# Altium schematic sheet 02_Power.SchDoc
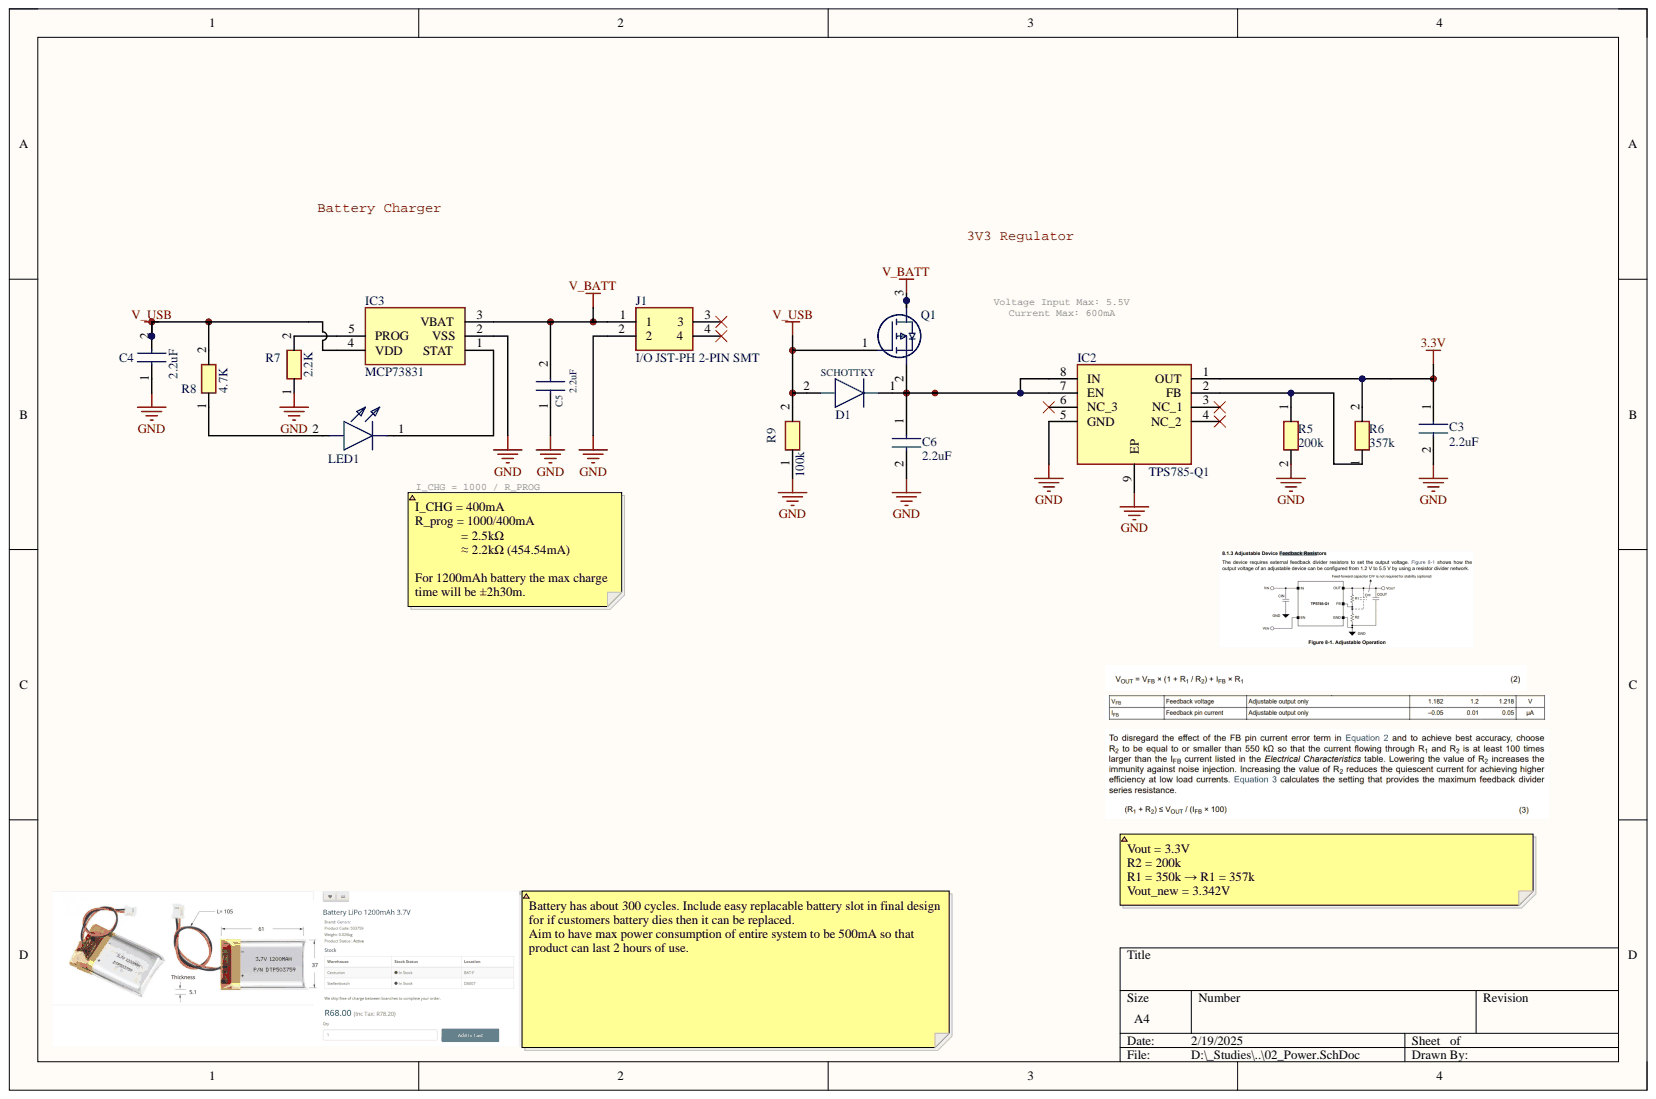
[diagram p0: 02_Power.SchDoc]

## Parts
C3  2.2uF  [CAPC2012X135N]
C4  2.2uF  [CAPC2012X135N]
C5  2.2uF  [CAPC2012X135N]
C6  2.2uF  [CAPC2012X135N]
D1  SCHOTTKY  [SOD2512X113N]
IC2  TPS785-Q1  [SON65P300X300X100-9N-D]
IC3  MCP73831  [SOT95P270X145-5N]
J1  I/O JST-PH 2-PIN SMT  [1769]
LED1  LED YELLOW 0805 SMD  [LYM676Q2S126Z]  (value not drawn)
Q1  MOSFET P-CH ENH FET -20V 52mOh -5A SMD  [SOT96P240X115-3N]  (value not drawn)
R5  200k  [RESC2012X60N]
R6  357k  [ERJ6ENF1000V]
R7  2.2K  [RESC2012X70N]
R8  4.7K  [RESC2012X50N]
R9  100k  [RESC2012X50N]

## Nets
3.3V: C3.1 IC2.1(OUT) R6.2
GND: C3.2 C4.1 C5.1 C6.2 IC2.5(GND) IC2.9(EP) IC3.2(VSS) J1.2 R5.2 R7.1 R9.1
V_BATT: C5.2 IC3.3(VBAT) J1.1 Q1.3
V_USB: C4.2 D1.2 IC3.4(VDD) Q1.1 R8.2 R9.2
NetC6_1: C6.1 D1.1 IC2.7(EN) IC2.8(IN) Q1.2
NetIC2_2: IC2.2(FB) R5.1 R6.1
NetIC3_1: IC3.1(STAT) LED1.1
NetIC3_5: IC3.5(PROG) R7.2
NetLED1_2: LED1.2 R8.1

Not connected: IC2 3(NC_1) 4(NC_2) 6(NC_3); J1 3 4

## Library data of the parts
C3-C6 = CAP CER 2u2F 0805 SMD: Capacitor; Manufacturer_Name: TAIYO YUDEN; Manufacturer_Part_Number: LMK212BJ225MG-T; Component Kind: Standard; Datasheet Link: https://ds.yuden.co.jp/TYCOMPAS/ut/download?pn=LMK063BBJ474MPLF&fileType=CA; Height: 1.35mm; Mouser Part Number: 963-LMK212BJ225MG-T; Mouser Price/Stock: https://www.mouser.com/Search/Refine.aspx?Keyword=963-LMK212BJ225MG-T; Library Name: BraaiBuddyPCB_Schematic_Library.SCHLIB
D1 = DIODE SCHOTTKY 1A 23V 620mV SMD: Diode; Manufacturer_Name: STMicroelectronics; Manufacturer_Part_Number: BAT20JFILM; Arrow Part Number: BAT20JFILM; Arrow Price/Stock: https://www.arrow.com/en/products/bat20jfilm/stmicroelectronics?utm_currency=USD&region=nac; Component Kind: Standard; ComponentLink1Description: Datasheet; ComponentLink1URL: http://www.st.com/web/en/resource/technical/document/datasheet/CD00012201.pdf; Datasheet Link: http://www.st.com/web/en/resource/technical/document/datasheet/CD00012201.pdf; Height: 1.13mm; Mouser Part Number: 511-BAT20JFILM; Mouser Price/Stock: https://www.mouser.co.uk/ProductDetail/STMicroelectronics/BAT20JFILM?qs=6cWmAGMIEBzKXM4JcoRdbw%3D%3D; Library Name: BraaiBuddyPCB_Schematic_Library.SCHLIB
IC2 = IC LDO 1A 5V5 ADJ SMD SON-8: LDO Voltage Regulators Automotive 1-A high -accuracy adjustable; Manufacturer_Name: Texas Instruments; Manufacturer_Part_Number: TPS78501QWDRBRQ1; Arrow Part Number: TPS78501QWDRBRQ1; Arrow Price/Stock: https://www.arrow.com/en/products/tps78501qwdrbrq1/texas-instruments?region=nac; Component Kind: Standard; ComponentLink1Description: Datasheet; ComponentLink1URL: https://www.ti.com/lit/gpn/TPS785-Q1; Datasheet Link: https://www.ti.com/lit/gpn/TPS785-Q1; Height: 1mm; Mouser Part Number: 595-TPS78501QWDRBRQ1; Mouser Price/Stock: https://www.mouser.co.uk/ProductDetail/Texas-Instruments/TPS78501QWDRBRQ1?qs=iLbezkQI%252Bsjtafwbr0PHJA%3D%3D; Library Name: BraaiBuddyPCB_Schematic_Library.SCHLIB
IC3 = IC BATT CHG MGNT Li-Po 4V2 REG SMD: Integrated Circuit; Manufacturer_Name: Microchip; Manufacturer_Part_Number: MCP73831T-2ACI/OT; Arrow Part Number: MCP73831T-2ACI/OT; Arrow Price/Stock: https://www.arrow.com/en/products/mcp73831t-2aciot/microchip-technology?utm_currency=USD&region=nac; Component Kind: Standard; ComponentLink1Description: Datasheet; ComponentLink1URL: http://ww1.microchip.com/downloads/en/DeviceDoc/20001984g.pdf; Datasheet Link: http://ww1.microchip.com/downloads/en/DeviceDoc/20001984g.pdf; Height: 1.45mm; Mouser Part Number: 579-MCP73831T-2ACIOT; Mouser Price/Stock: https://www.mouser.co.uk/ProductDetail/Microchip-Technology/MCP73831T-2ACI-OT?qs=yUQqVecv4qvbBQBGbHx0Mw%3D%3D; Library Name: BraaiBuddyPCB_Schematic_Library.SCHLIB
J1 = I/O JST-PH 2-PIN SMT: Connector; Manufacturer_Name: Adafruit; Arrow Part Number: 1769; Arrow Price/Stock: https://www.arrow.com/en/products/1769/adafruit-industries?utm_currency=USD&region=nac; Component Kind: Standard; Datasheet Link: https://cdn-shop.adafruit.com/datasheets/17311.pdf; Height: 5.5mm; Manufacturer_Part_Number: 1769; Mouser Part Number: 485-1769; Mouser Price/Stock: https://www.mouser.co.uk/ProductDetail/Adafruit/1769?qs=GURawfaeGuBbgoGtf2XstA%3D%3D; Library Name: BraaiBuddyPCB_Schematic_Library.SCHLIB
LED1 = LED YELLOW 0805 SMD: Single Colour LED Yellow 20mA; Manufacturer_Name: ams OSRAM; Manufacturer_Part_Number: LY M676-Q2S1-26-Z; Component Kind: Standard; ComponentLink1Description: Datasheet; ComponentLink1URL: https://www.mouser.com/datasheet/2/588/Osram_7222021_LY__M676_EN-2891431.pdf; Datasheet Link: https://www.mouser.com/datasheet/2/588/Osram_7222021_LY__M676_EN-2891431.pdf; Height: 1.4mm; Mouser Part Number: 720-LYM676Q2S126Z; Mouser Price/Stock: https://www.mouser.co.uk/ProductDetail/ams-OSRAM/LY-M676-Q2S1-26-Z?qs=nTDll3UaDK64U7BuhoNaqQ%3D%3D; Library Name: BraaiBuddyPCB_Schematic_Library.SCHLIB
Q1 = MOSFET P-CH ENH FET -20V 52mOh -5A SMD: MOSFET (P-Channel); Manufacturer_Name: Diodes Incorporated; Manufacturer_Part_Number: DMG2305UX-7; Arrow Part Number: DMG2305UX-7; Arrow Price/Stock: https://www.arrow.com/en/products/dmg2305ux-7/diodes-incorporated?utm_currency=USD&region=europe; Component Kind: Standard; ComponentLink1Description: Datasheet; ComponentLink1URL: https://www.diodes.com/assets/Datasheets/DMG2305UX.pdf; Datasheet Link: https://www.diodes.com//assets/Datasheets/DMG2305UX.pdf; Height: 1.15mm; Mouser Part Number: 621-DMG2305UX-7; Mouser Price/Stock: https://www.mouser.co.uk/ProductDetail/Diodes-Incorporated/DMG2305UX-7?qs=L1DZKBg7t5F%2FNBHrjfxC%252Bg%3D%3D; Library Name: BraaiBuddyPCB_Schematic_Library.SCHLIB
R5 = RES 200K 0805 SMD: Resistor; Manufacturer_Name: KOA Speer; Manufacturer_Part_Number: SG73P2ATTD2003F; Component Kind: Standard; Datasheet Link: https://www.mouser.ch/datasheet/2/219/SG73P-1829847.pdf; Height: 0.6mm; Mouser Part Number: 660-SG73P2ATTD2003F; Mouser Price/Stock: https://www.mouser.co.uk/ProductDetail/KOA-Speer/SG73P2ATTD2003F?qs=0U9fANxrh6nXGXRg%2FonPow%3D%3D; Library Name: BraaiBuddyPCB_Schematic_Library.SCHLIB
R6 = RES 357K 0805 SMD: Resistor; Manufacturer_Name: Panasonic; Manufacturer_Part_Number: ERJ-6ENF3573V; Component Kind: Standard; ComponentLink1Description: Datasheet; ComponentLink1URL: https://industrial.panasonic.com/cdbs/www-data/pdf/RDA0000/AOA0000C84.pdf; Datasheet Link: https://industrial.panasonic.com/cdbs/www-data/pdf/RDA0000/AOA0000C84.pdf; Height: 0.7mm; Mouser Part Number: 667-ERJ-6ENF3573V; Mouser Price/Stock: http://www.mouser.com/Search/ProductDetail.aspx?qs=50QC8w71jAs0wPzf%2f68dcA%3d%3d; Library Name: BraaiBuddyPCB_Schematic_Library.SCHLIB
R7 = RES 2.2K 0805 SMD: Resistor; Manufacturer_Name: Panasonic; Manufacturer_Part_Number: ERJ-PB6B2201V; Component Kind: Standard; Datasheet Link: https://componentsearchengine.com/Datasheets/1/ERJ-PB6B2201V.pdf; Height: 0.7mm; Mouser Part Number: 667-ERJ-PB6B2201V; Mouser Price/Stock: https://www.mouser.co.uk/ProductDetail/Panasonic/ERJ-PB6B2201V?qs=eFOtW6xYNWErIH4WIewwIQ%3D%3D; Library Name: BraaiBuddyPCB_Schematic_Library.SCHLIB
R8 = RES 4.7K 0805 SMD: Resistor; Manufacturer_Name: Vishay; Manufacturer_Part_Number: CRCW08054K70FKEA; Arrow Part Number: CRCW08054K70FKEA; Arrow Price/Stock: https://www.arrow.com/en/products/crcw08054k70fkea/vishay?region=europe; Component Kind: Standard; Datasheet Link: http://www.vishay.com/docs/20035/dcrcwe3.pdf; Height: 0.5mm; Mouser Part Number: 71-CRCW0805-4.7K-E3; Mouser Price/Stock: https://www.mouser.co.uk/ProductDetail/Vishay-Dale/CRCW08054K70FKEA?qs=kkyyugUlSrMIzNiWjd4LGQ%3D%3D; Library Name: BraaiBuddyPCB_Schematic_Library.SCHLIB
R9 = RES 100K 0805 SMD: Resistor; Manufacturer_Name: Vishay; Manufacturer_Part_Number: CRCW0805100KJNECC; Arrow Part Number: CRCW0805100KJNECC; Arrow Price/Stock: https://www.arrow.com/en/products/crcw0805100kjnecc/vishay?region=nac; Component Kind: Standard; Datasheet Link: https://www.arrow.com/en/products/crcw0805100kjnecc/vishay?region=nac; Height: 0.5mm; Mouser Part Number: 71-CRCW0805100KJNECC; Mouser Price/Stock: https://www.mouser.co.uk/ProductDetail/Vishay-Dale/CRCW0805100KJNECC?qs=wd5RIQLrsJj4eBrPbx2Sww%3D%3D; Library Name: BraaiBuddyPCB_Schematic_Library.SCHLIB
